# Altium schematic sheet dos.SchDoc(dos)
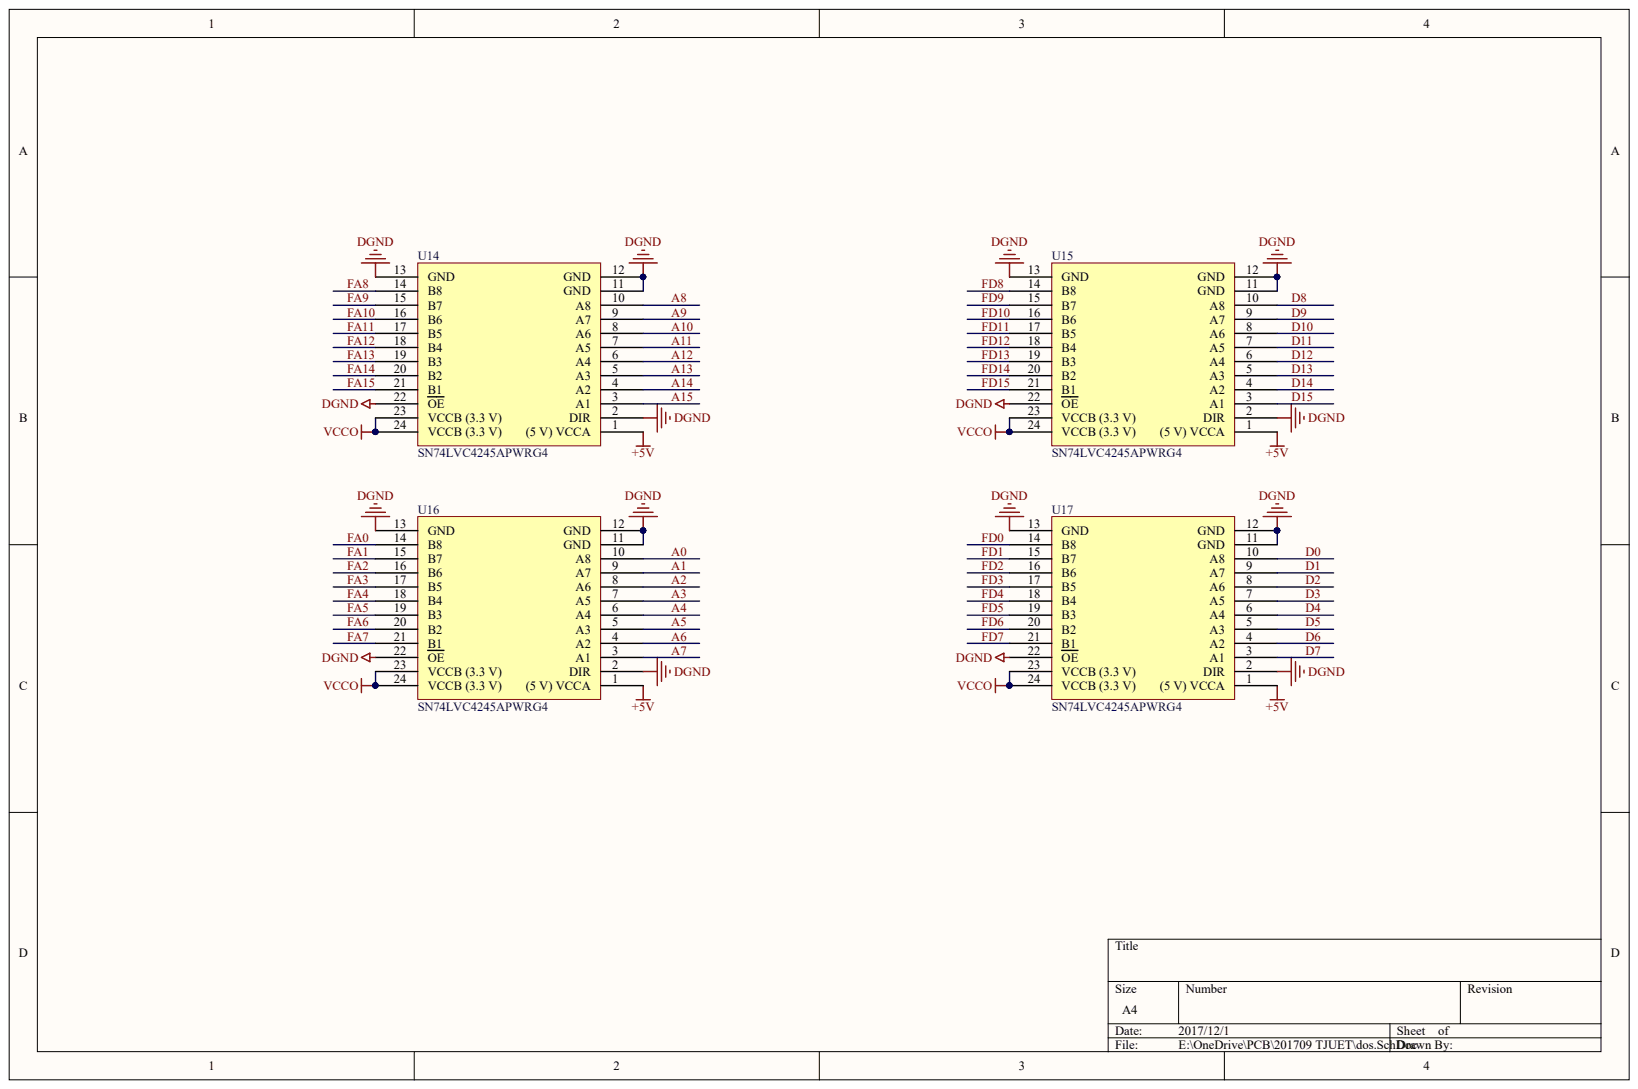
[diagram p0: dos.SchDoc]

## Parts
U14  SN74LVC4245APWRG4
U15  SN74LVC4245APWRG4
U16  SN74LVC4245APWRG4
U17  SN74LVC4245APWRG4

## Nets
+5V: U14.1((5_V)_VCCA) U15.1((5_V)_VCCA) U16.1((5_V)_VCCA) U17.1((5_V)_VCCA)
DGND: U14.2(DIR) U14.11(GND) U14.12(GND) U14.13(GND) U14.22(OE) U15.2(DIR) U15.11(GND) U15.12(GND) U15.13(GND) U15.22(OE) U16.2(DIR) U16.11(GND) U16.12(GND) U16.13(GND) U16.22(OE) U17.2(DIR) U17.11(GND) U17.12(GND) U17.13(GND) U17.22(OE)
VCCO: U14.23(VCCB_(3.3_V)) U14.24(VCCB_(3.3_V)) U15.23(VCCB_(3.3_V)) U15.24(VCCB_(3.3_V)) U16.23(VCCB_(3.3_V)) U16.24(VCCB_(3.3_V)) U17.23(VCCB_(3.3_V)) U17.24(VCCB_(3.3_V))
A0: U16.10(A8)
A1: U16.9(A7)
A2: U16.8(A6)
A3: U16.7(A5)
A4: U16.6(A4)
A5: U16.5(A3)
A6: U16.4(A2)
A7: U16.3(A1)
A8: U14.10(A8)
A9: U14.9(A7)
A10: U14.8(A6)
A11: U14.7(A5)
A12: U14.6(A4)
A13: U14.5(A3)
A14: U14.4(A2)
A15: U14.3(A1)
D0: U17.10(A8)
D1: U17.9(A7)
D2: U17.8(A6)
D3: U17.7(A5)
D4: U17.6(A4)
D5: U17.5(A3)
D6: U17.4(A2)
D7: U17.3(A1)
D8: U15.10(A8)
D9: U15.9(A7)
D10: U15.8(A6)
D11: U15.7(A5)
D12: U15.6(A4)
D13: U15.5(A3)
D14: U15.4(A2)
D15: U15.3(A1)
FA0: U16.14(B8)
FA1: U16.15(B7)
FA2: U16.16(B6)
FA3: U16.17(B5)
FA4: U16.18(B4)
FA5: U16.19(B3)
FA6: U16.20(B2)
FA7: U16.21(B1)
FA8: U14.14(B8)
FA9: U14.15(B7)
FA10: U14.16(B6)
FA11: U14.17(B5)
FA12: U14.18(B4)
FA13: U14.19(B3)
FA14: U14.20(B2)
FA15: U14.21(B1)
FD0: U17.14(B8)
FD1: U17.15(B7)
FD2: U17.16(B6)
FD3: U17.17(B5)
FD4: U17.18(B4)
FD5: U17.19(B3)
FD6: U17.20(B2)
FD7: U17.21(B1)
FD8: U15.14(B8)
FD9: U15.15(B7)
FD10: U15.16(B6)
FD11: U15.17(B5)
FD12: U15.18(B4)
FD13: U15.19(B3)
FD14: U15.20(B2)
FD15: U15.21(B1)

## Library data of the parts
U14-U17 = (no symbol name): ComponentLink1Description: 供应商链接; ComponentLink1URL: http://www.szlcsc.com/product/details_8336.html; Package: TSSOP-24; Supplier: LC; SuppliersPartNumber: C7859
